# Altium schematic sheet SH1 - CONNECTORS.SchDoc
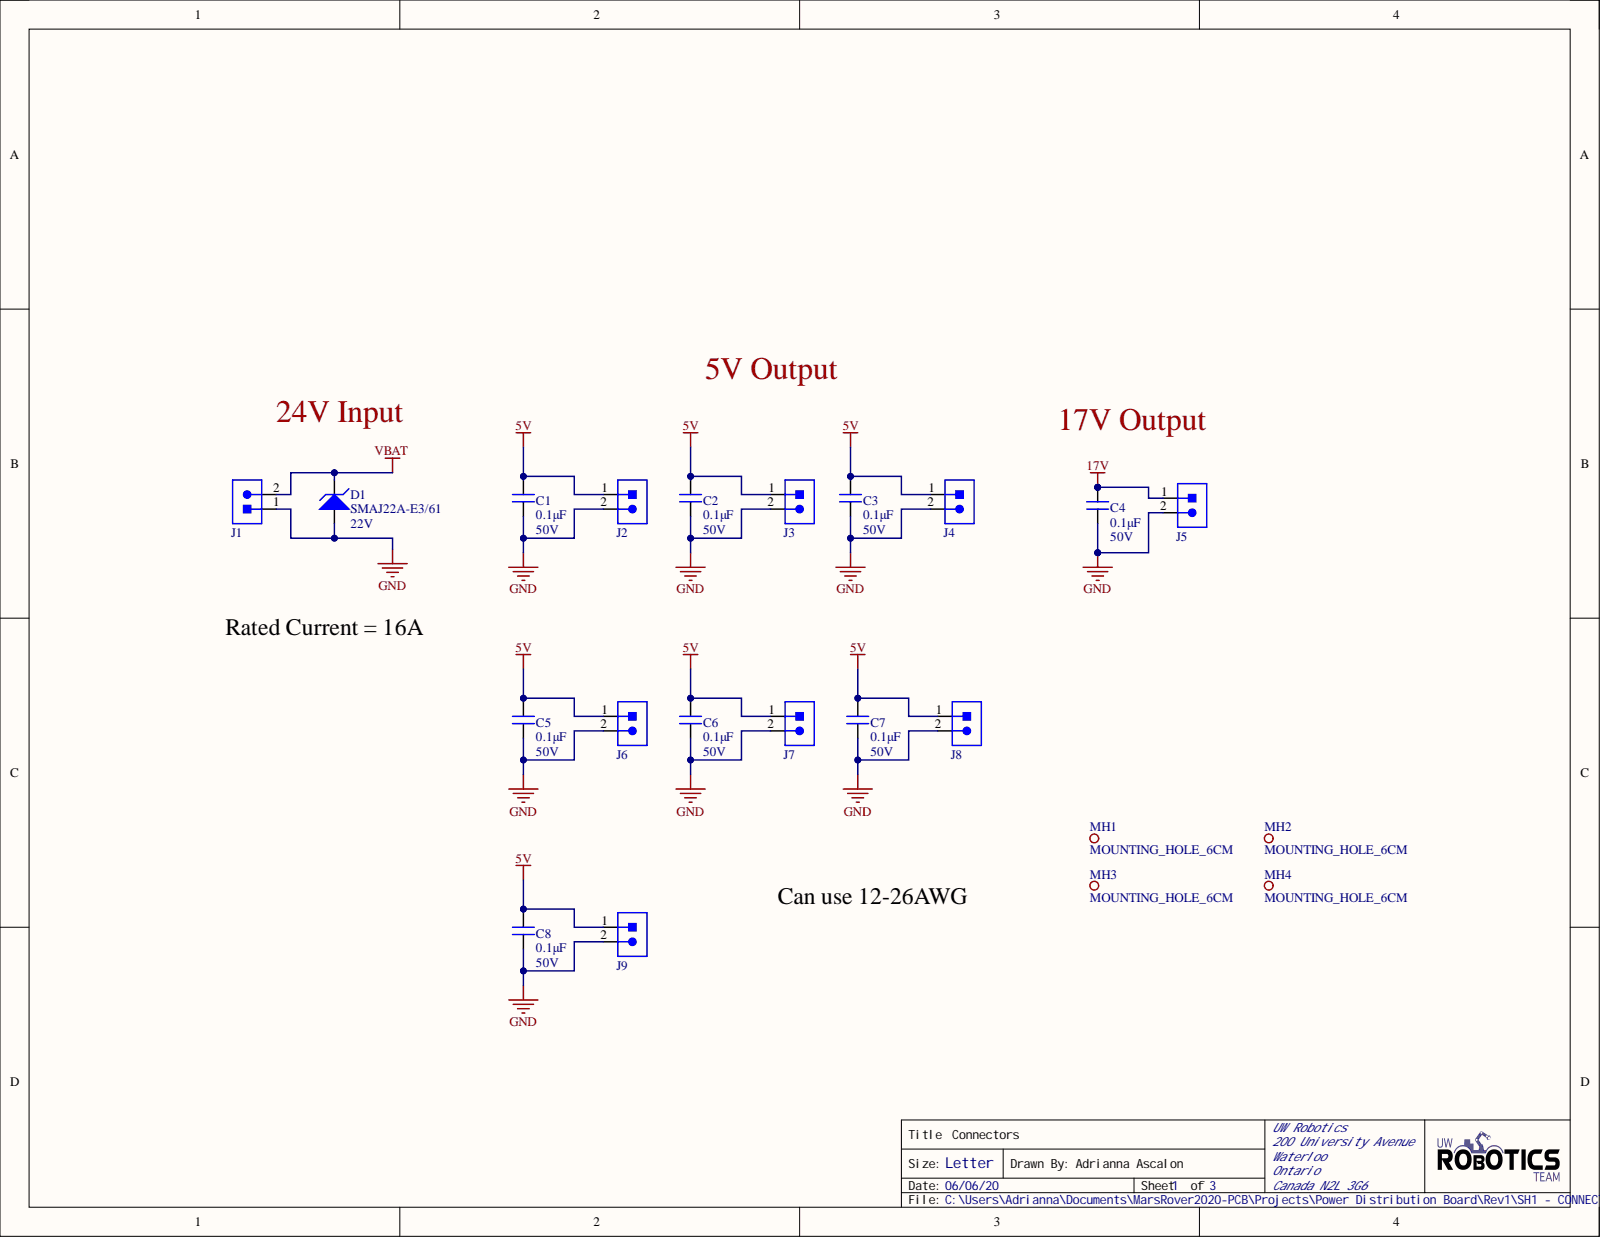
[diagram p0: SH1 - CONNECTORS.SchDoc]

## Parts
C1  0.1μF  Voltage Rating: 50V  [CAPC2012X140N]
C2  0.1μF  Voltage Rating: 50V  [CAPC2012X140N]
C3  0.1μF  Voltage Rating: 50V  [CAPC2012X140N]
C4  0.1μF  Voltage Rating: 50V  [CAPC2012X140N]
C5  0.1μF  Voltage Rating: 50V  [CAPC2012X140N]
C6  0.1μF  Voltage Rating: 50V  [CAPC2012X140N]
C7  0.1μF  Voltage Rating: 50V  [CAPC2012X140N]
C8  0.1μF  Voltage Rating: 50V  [CAPC2012X140N]
D1  SMAJ22A-E3/61  Operating Supply Voltage: 22V  [DO-214AC_UNI]
J1  1935161  [1935161]  (value not drawn)
J2  1935161  [1935161]  (value not drawn)
J3  1935161  [1935161]  (value not drawn)
J4  1935161  [1935161]  (value not drawn)
J5  1935161  [1935161]  (value not drawn)
J6  1935161  [1935161]  (value not drawn)
J7  1935161  [1935161]  (value not drawn)
J8  1935161  [1935161]  (value not drawn)
J9  1935161  [1935161]  (value not drawn)
MH1  MOUNTING_HOLE_6CM  [MOUNTING_HOLE]
MH2  MOUNTING_HOLE_6CM  [MOUNTING_HOLE]
MH3  MOUNTING_HOLE_6CM  [MOUNTING_HOLE]
MH4  MOUNTING_HOLE_6CM  [MOUNTING_HOLE]

## Nets
5V: C1.2 C2.2 C3.2 C5.2 C6.2 C7.2 C8.2 J2.1 J3.1 J4.1 J6.1 J7.1 J8.1 J9.1
17V: C4.2 J5.1
GND: C1.1 C2.1 C3.1 C4.1 C5.1 C6.1 C7.1 C8.1 D1.1 J1.1 J2.2 J3.2 J4.2 J5.2 J6.2 J7.2 J8.2 J9.2
VBAT: D1.2 J1.2

## Library data of the parts
C1-C8 = CAP_0.1uF_50V_0805: CAP CER 0.1UF 50V X7R 0805; Manufacturer 1: Murata Electronics; Manufacturer Part Number 1: GCD21BR71H104KA01L; Tolerance: 10%; Case Code (Imperial): 0805; Case Code (Metric): 2012; Component Kind: Standard; Depth: 1.25mm; Dielectric: X7R; Height: 1.25mm; Length: 2mm; Max Operating Temperature: 125°C; Min Operating Temperature: -55°C; Mount: Surface Mount; Package: 0805 (2012 Metric); Ratings: AEC-Q200; Supplier 1: Digi-Key; Supplier Part Number 1: 490-11955-1-ND; Termination: SMD/SMT; Thickness: 0.049inch; Value: 0.1μF; Width: 0.049inch; Library Name: UWRT_Schematic_Library_2020.SchLib
D1 = SMAJ22A-E3/61: TVS DIODE 22V 35.5VC SMA; Manufacturer 1: Vishay Semiconductors; Breakdown Voltage: 24.4V; Clamping Voltage: 35.5V; Current: 113A; Direction: Unidirectional; Element Configuration: Single; Leakage Current: 1uA; Max Breakdown Voltage: 26.9V; Max Forward Surge Current (Ifsm): 40A; Max Power Dissipation: 400W; Max Surge Current: 11.3A; Number of Channels: 1; Test Current: 1mA; Working Voltage: 3.5V; Case/Package: SMA; Component Kind: Standard; Height: 0.09inch; Length: 0.177inch; Manufacturer Part Number 1: SMAJ22A-E3/61; Max Operating Temperature: 150°C; Max Reverse Leakage Current: 1uA; Min Breakdown Voltage: 24.4V; Min Operating Temperature: -55°C; Number of Elements: 1; Number of Pins: 2; Peak Pulse Current: 11.3A; Peak Pulse Power: 400W; Polarity: Unidirectional; Power Dissipation: 400W; REACH SVHC: Unknown; Reverse Breakdown Voltage: 24.4V; Reverse Standoff Voltage: 22V; Supplier 1: Digi-Key; Supplier Part Number 1: SMAJ22A-E3/61GICT-ND; Termination: SMD/SMT; Voltage: 22V; Voltage Rating (DC): 22V; Width: 0.11inch; Library Name: UWRT_Schematic_Library_2020.SchLib
J1-J9 = CON_2M_TERM: TERM BLK 2POS SIDE ENTRY 5MM; Manufacturer 1: Phoenix Contact; Approvals: UL; Connector Type: Screw; Current: 18A; Current Rating: 17.5A; Max Current Rating: 16A; Max Supply Voltage: 250V; Number of Circuits: 2; Number of Levels: 1; Voltage: 300V; Voltage Rating (DC): 320V; Wire Gauge (Max): 26AWG; Wire Gauge (Min): 12AWG; Color: Green; Component Kind: Standard; Contact Material: Copper,Tin; Depth: 9mm; Flammability Rating: UL94 V-0; Height: 11.3mm; Housing Color: Green; Housing Material: Polyamide; Insulation Material: Nylon; Length: 9mm; Manufacturer Part Number 1: 1935161; Material: Nylon; Mount: Through Hole; Number of Contacts: 2; Number of Pins: 2; Number of Poles: 2; Number of Positions: 2; Number of Positions Per Level: 2; Number of Rows: 1; Orientation: Straight; Pitch: 5mm; REACH SVHC: Unknown; Supplier 1: Digi-Key; Supplier Part Number 1: 277-1667-ND; Termination: Solder; Voltage Rating: 250V; Weight: 0.067021oz; Width: 14.9mm; Wire/Cable Gauge: 26AWG; Library Name: UWRT_Schematic_Library_2020.SchLib
MH1-MH4 = MOUNTING_HOLE_6CM: Component Kind: Standard; Description: MOUNTING_HOLE_6CM; Library Name: UWRT_Schematic_Library_2020.SchLib
